# Altium schematic sheet Boom Deployment.SchDoc("Boom Deployment")
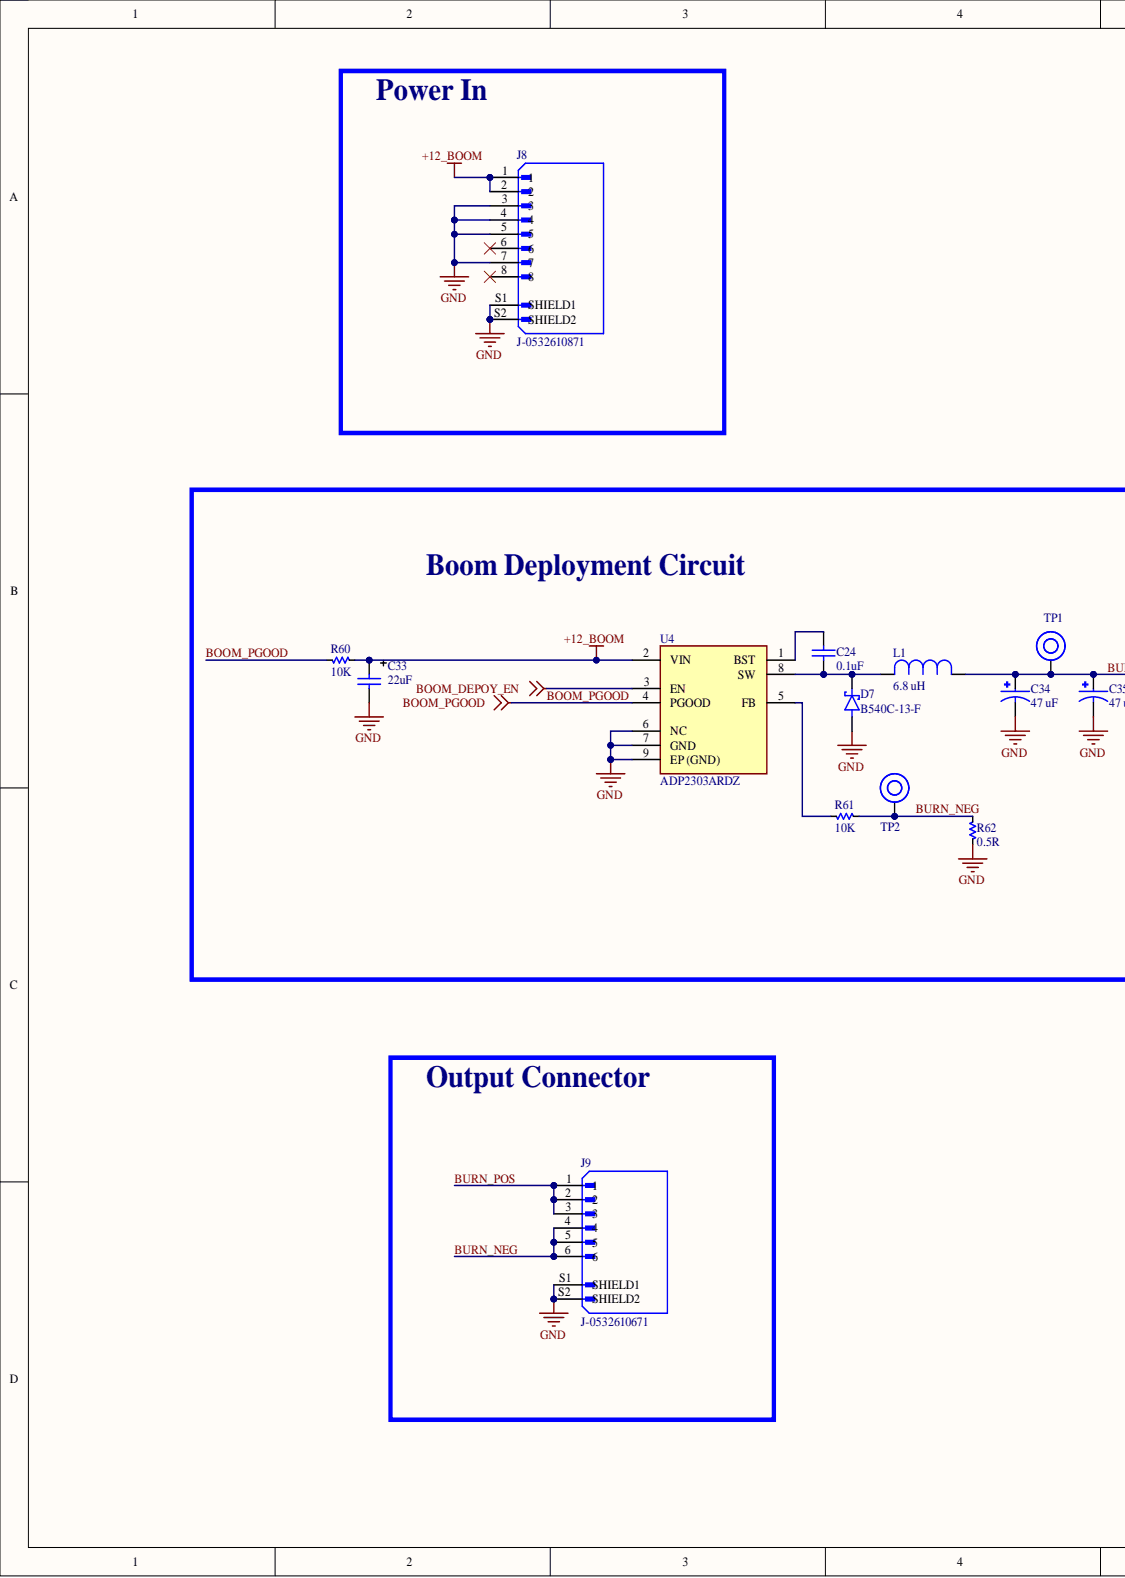
[diagram p0: Boom Deployment.SchDoc, left]
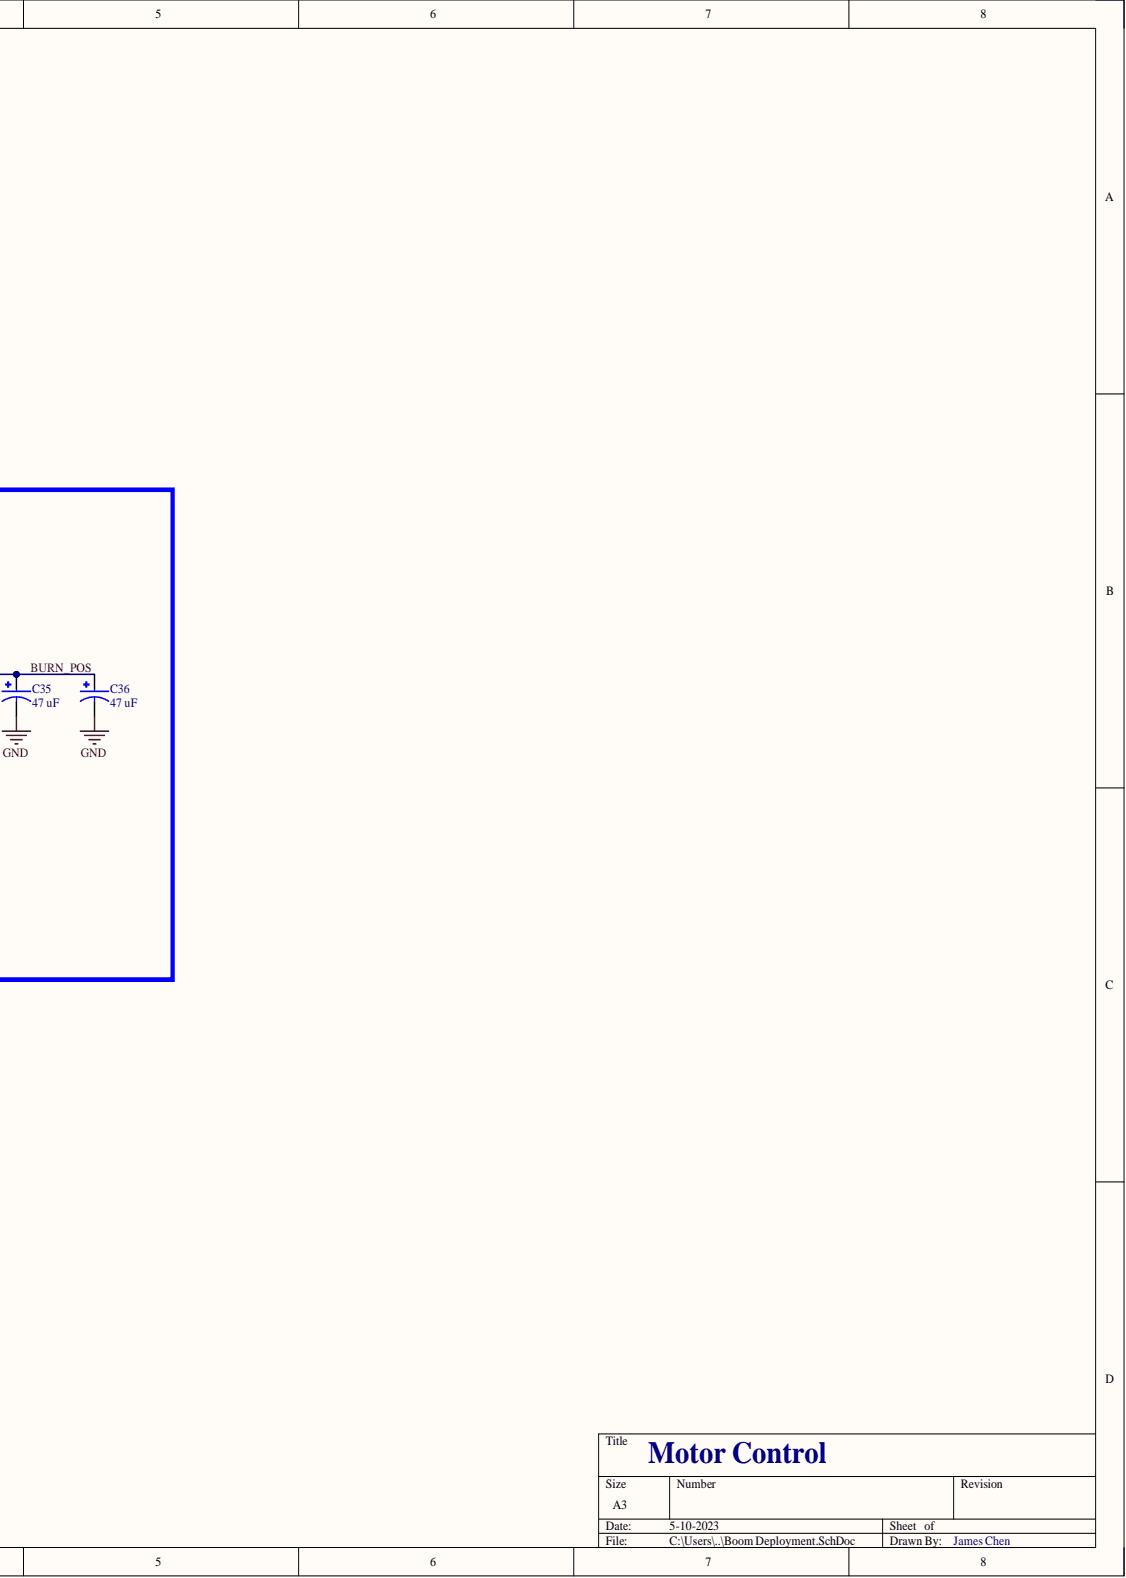
[diagram p1: Boom Deployment.SchDoc, right]

## Parts
C24  0.1uF  [0603]
C33  22uF  [CAP TANT 1206 (A) TCJ]
C34  47 uF  [CC-25SVPF47M]
C35  47 uF  [CC-25SVPF47M]
C36  47 uF  [CC-25SVPF47M]
D7  B540C-13-F  [D-B540C-13-F]
J8  J-0532610871  [J-532610871]
J9  J-0532610671  [J-0532610671]
L1  6.8 UH  [L-IHLP4040DZER6R8M01]
R60  10K  [0603]
R61  10K  [0603]
R62  0.5R  [2010]
TP1  TP  [TP]
TP2  TP  [TP]
U4  ADP2303ARDZ  [U-ADP2303ARDZ]

## Nets
+12_BOOM: C33.1 J8.1 J8.2 R60.2 U4.2(VIN)
BOOM_DEPOY_EN: U4.3(EN)
GND: C33.2 C34.2 C35.2 C36.2 D7.A J8.3 J8.4 J8.5 J8.7 J8.S1(SHIELD1) J8.S2(SHIELD2) J9.S1(SHIELD1) J9.S2(SHIELD2) R62.1 U4.6(NC) U4.7(GND) U4.9(EP_(GND))
BOOM_PGOOD: R60.1 U4.4(PGOOD)
BURN_NEG: J9.4 J9.5 J9.6 R61.2 R62.2 TP2.1
BURN_POS: C34.1 C35.1 C36.1 J9.1 J9.2 J9.3 L1.1 TP1.1
NetC24_1: C24.1 D7.C L1.2 U4.8(SW)
NetC24_2: C24.2 U4.1(BST)
NetR61_1: R61.1 U4.5(FB)

Not connected: J8 6 8

## Library data of the parts
C24 = CC-104-K-25V-X7R-0603: 0.1 µF ±10% 25V Ceramic Capacitor X7R 0603 (1608 Metric); Manufacturer: Samsung Electro-Mechanics; Manufacturer Part #: CL10B104KA8NNNC; Component Kind: Standard; ComponentLink1description: Datasheet; ComponentLink1URL: https://ds.yuden.co.jp/TYCOMPAS/ut/detail?pn=TMK105BJ104KV-F%20%20&u=M; ComponentLink2description: Manufacturer Website; ComponentLink2URL: https://www.yuden.co.jp/or/; Distributor: Digikey; Distributor Part #: 1276-1006-1-ND; Part Description: 0.1 µF ±10% 25V Ceramic Capacitor X7R 0603 (1608 Metric); Value: 0.1uF; Library Name: Burn Wire Dev Board.SchLib
C33 = CT-225-M-20V-OTH-1206: CAP TANT 22UF 10% 10V 1206; Manufacturer: KYOCERA AVX; Manufacturer Part #: TPSA226K010T0900; Component Kind: Standard; ComponentLink1Description: Datasheet; ComponentLink2Description: Manufacturer Website; Distributor: Digikey; Distributor Part #: 478-11193-1-ND; Part Description: CAP TANT 22UF 10% 10V 1206; Value: 22uF; Library Name: Burn Wire Dev Board.SchLib
C34-C36 = CC-25SVPF47M: CAP ALUM POLY 47UF 20% 25V SMD; Manufacturer: Panasonic Electronic Components; Component Kind: Standard; ComponentLink1Description: Datasheet; ComponentLink2Description: Manufacture Website; Distributor: Digikey; Distributor Part #: P16513CT-ND; Manufacturer Part #: 25SVPF47M; Part Description: CAP ALUM POLY 47UF 20% 25V SMD; Value: 47 uF; Library Name: Burn Wire Dev Board.SchLib
D7 = D-B540C-13-F: DIODE SCHOTTKY 40V 5A SMC; Manufacturer: Diodes Incorporated; Component Kind: Standard; Distributor: Digikey; Distributor Part #: B540C-FDICT-ND; Manufacturer Part #: B540C-13-F; Library Name: Burn Wire Dev Board.SchLib
J8 = J-0532610871: CONN HEADER SMD R/A 8POS 1.25MM; Manfacturer: Molex; Component Kind: Standard; Distributor: Digi-Key; Distributor Part #: WM7626CT-ND; Manfacturer Part #: 0532610871; Library Name: OBC Development Board.SchLib
J9 = J-0532610671: CONN HEADER SMD R/A 6POS 1.25MM; Manfacturer: Molex; Component Kind: Standard; Distributor: Digi-Key; Distributor Part #: WM7624CT-ND; Manfacturer Part #: 0532610671; Library Name: Burn Wire Dev Board.SchLib
L1 = L-IHLP4040DZER6R8M01: FIXED IND 6.8UH 8A 23.3 MOHM SMD; Manufacturer: Vishay Dale; Component Kind: Standard; Distributor: Digikey; Distributor Part #: 541-1303-1-ND; Manufacturer Part #: IHLP4040DZER6R8M01; Value: 6.8 uH; Library Name: Burn Wire Dev Board.SchLib
R60 R61 = RT-10K0-F-1/16W-0603: RES 10K OHM 1% 1/8W 0603; Manufacturer: Stackpole Electronics Inc; Manufacturer Part #: RNCP0603FTD10K0; Component Kind: Standard; ComponentLink1description: Datasheet; ComponentLink1URL: https://www.vishay.com/docs/20035/dcrcwe3.pdf; ComponentLink2description: Manufacturer Website; ComponentLink2URL: https://www.vishay.com/; Distributor: Digikey; Distributor Part #: RNCP0603FTD10K0CT-ND; Part Description: RES 10K OHM 1% 1/8W 0603; Value: 10K; Library Name: Burn Wire Dev Board.SchLib
R62 = RT-0.5R0-F-1W-2010: RES 0.5 OHM 1% 1W 2010; Manufacturer: Vishay Dale; Manufacturer Part #: WSLT2010R5000FEA18; Component Kind: Standard; ComponentLink1description: Datasheet; ComponentLink1URL: https://www.vishay.com/docs/20035/dcrcwe3.pdf; ComponentLink2description: Manufacturer Website; ComponentLink2URL: https://www.vishay.com/; Distributor: Digikey; Distributor Part #: 541-10112-1-ND; Part Description: RES 0.5 OHM 1% 1W 2010; Value: 0.5R; Library Name: Burn Wire Dev Board.SchLib
TP1 TP2 = TP: Test Point; Component Kind: Standard; ComponentLink1description: Datasheet; ComponentLink1URL: https://industrial.panasonic.com/ww/products/resistors/chip-resistors/general-purpose-chip-resistors/models/ERJ2GE0R00X; ComponentLink2description: Manufacturer Website; ComponentLink2URL: https://industrial.panasonic.com/ww/products/resistors/chip-resistors/general-purpose-chip-resistors/models/ERJ2GE0R00X; Library Name: PowerManagmentBoard_V4.0.SchLib
U4 = U-ADP2303ARDZ: IC REG BUCK ADJUSTABLE 3A 8SOIC; Manufacturer: Analog Devices Inc.; Component Kind: Standard; Distributor: Digikey; Distributor Part #: 505-ADP2303ARDZ-ND; Manufacturer Part #: ADP2303ARDZ; Library Name: Burn Wire Dev Board.SchLib
